# Altium schematic sheet 06_KEY.SchDoc
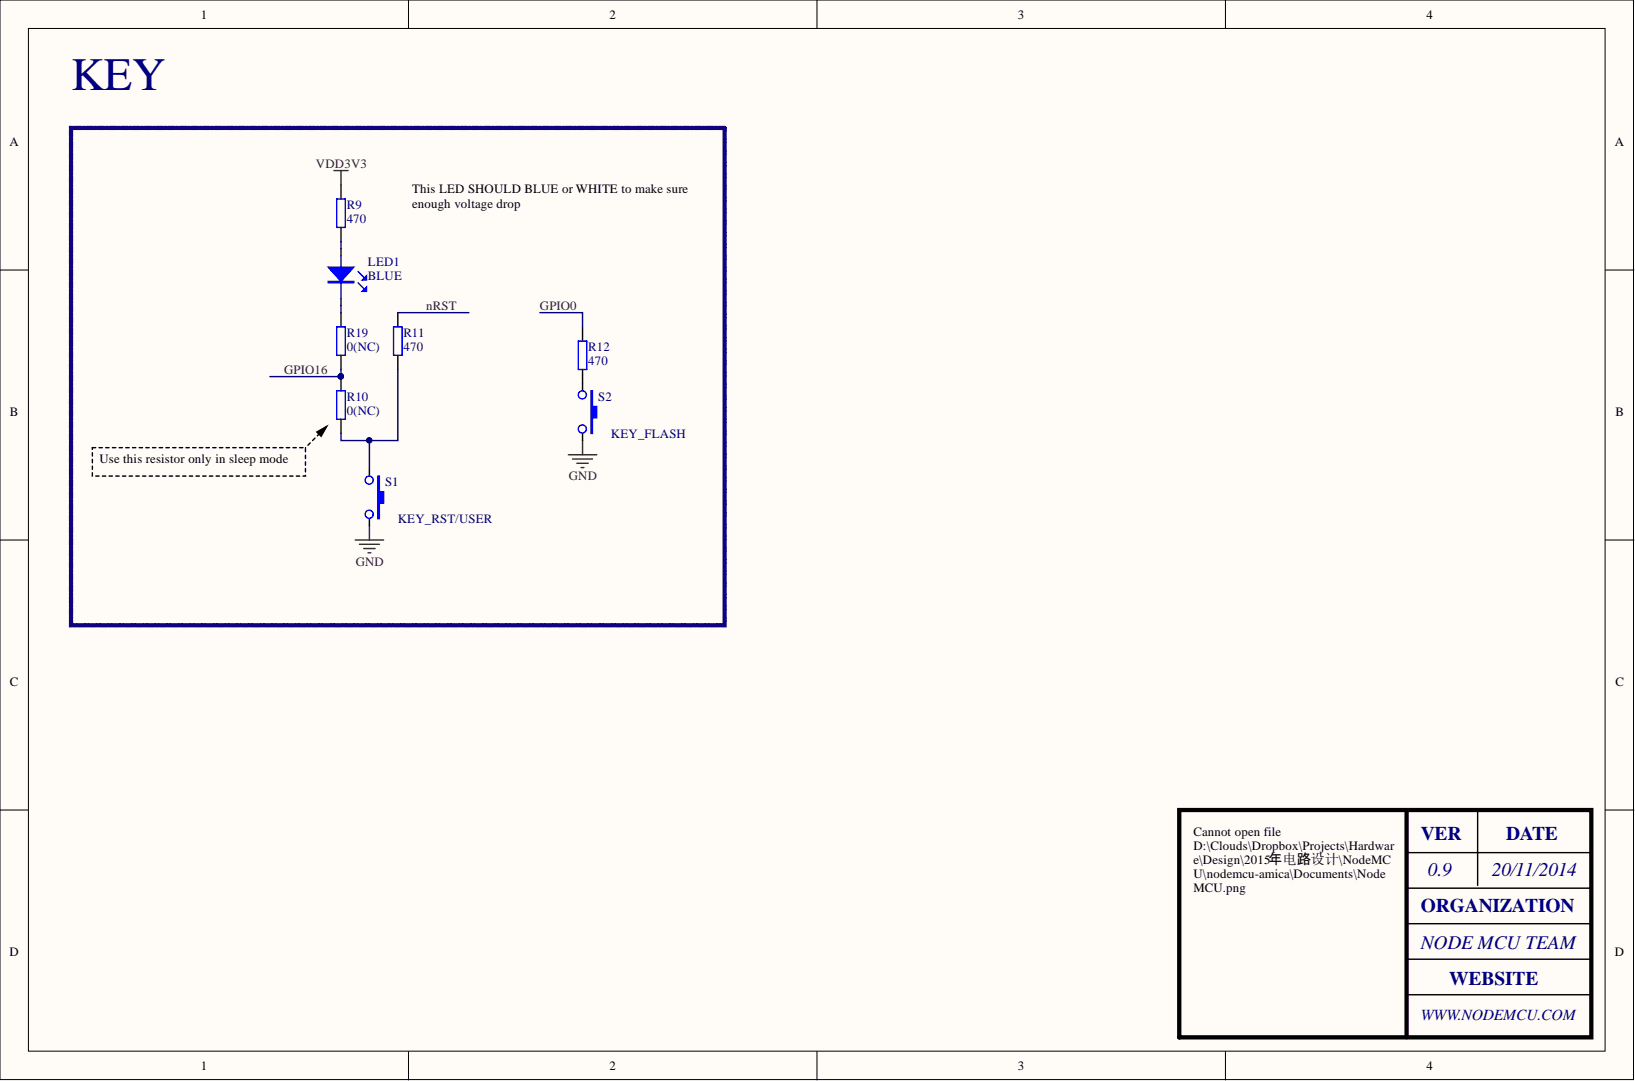
[diagram p0: 06_KEY.SchDoc]

## Parts
LED1  BLUE  [SMT_LED_0603]
R9  470  [SMT_R_0402]
R10  0(NC)  [SMT_R_0402]
R11  470  [SMT_R_0402]
R12  470  [SMT_R_0402]
R19  0(NC)  [SMT_R_0402]
S1  KEY_RST/USER  [SMT_SW_PTS_820]
S2  KEY_FLASH  [SMT_SW_PTS_820]

## Nets
GND: S1.2 S2.2
VDD3V3: R9.2
GPIO0: R12.2
GPIO16: R10.2 R19.1
NRST: R11.2
NetLED1_1: LED1.1 R9.1
NetLED1_2: LED1.2 R19.2
NetR10_1: R10.1 R11.1 S1.1
NetR12_1: R12.1 S2.1

## Library data of the parts
LED1 = SMT_LED_0603: SMT LED; Component Kind: Standard; Library Name: Vowstar_Basic_Device.IntLib
R9-R12 R19 = SMT_R_0402: Surface mount resistor 0402; Component Kind: Standard; Library Name: Vowstar_Basic_Device.IntLib
S1 S2 = SMT_SW_PTS_820: SMT Tactile ‎Switch PTS 820 Series; Component Kind: Standard; Library Name: Vowstar_Switch.IntLib
